# Altium schematic sheet SectionC_BreakOut.SchDoc(SectionC_BreakOut)
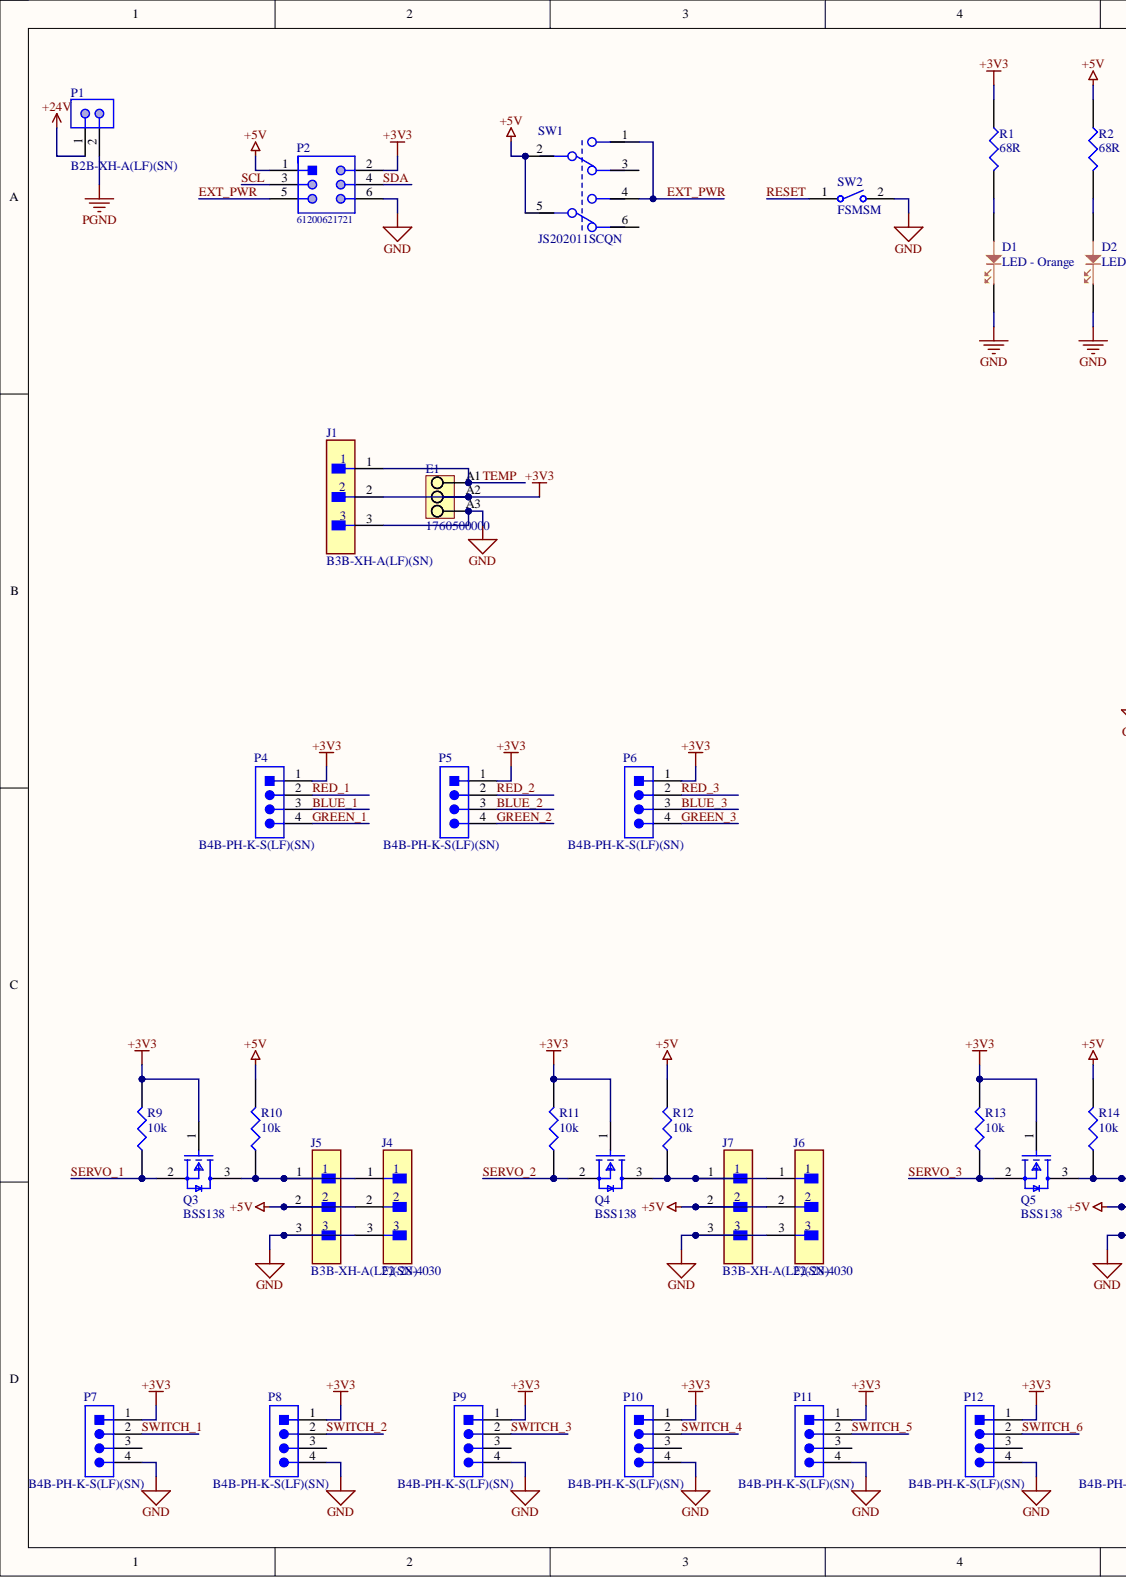
[diagram p0: SectionC_BreakOut.SchDoc, left]
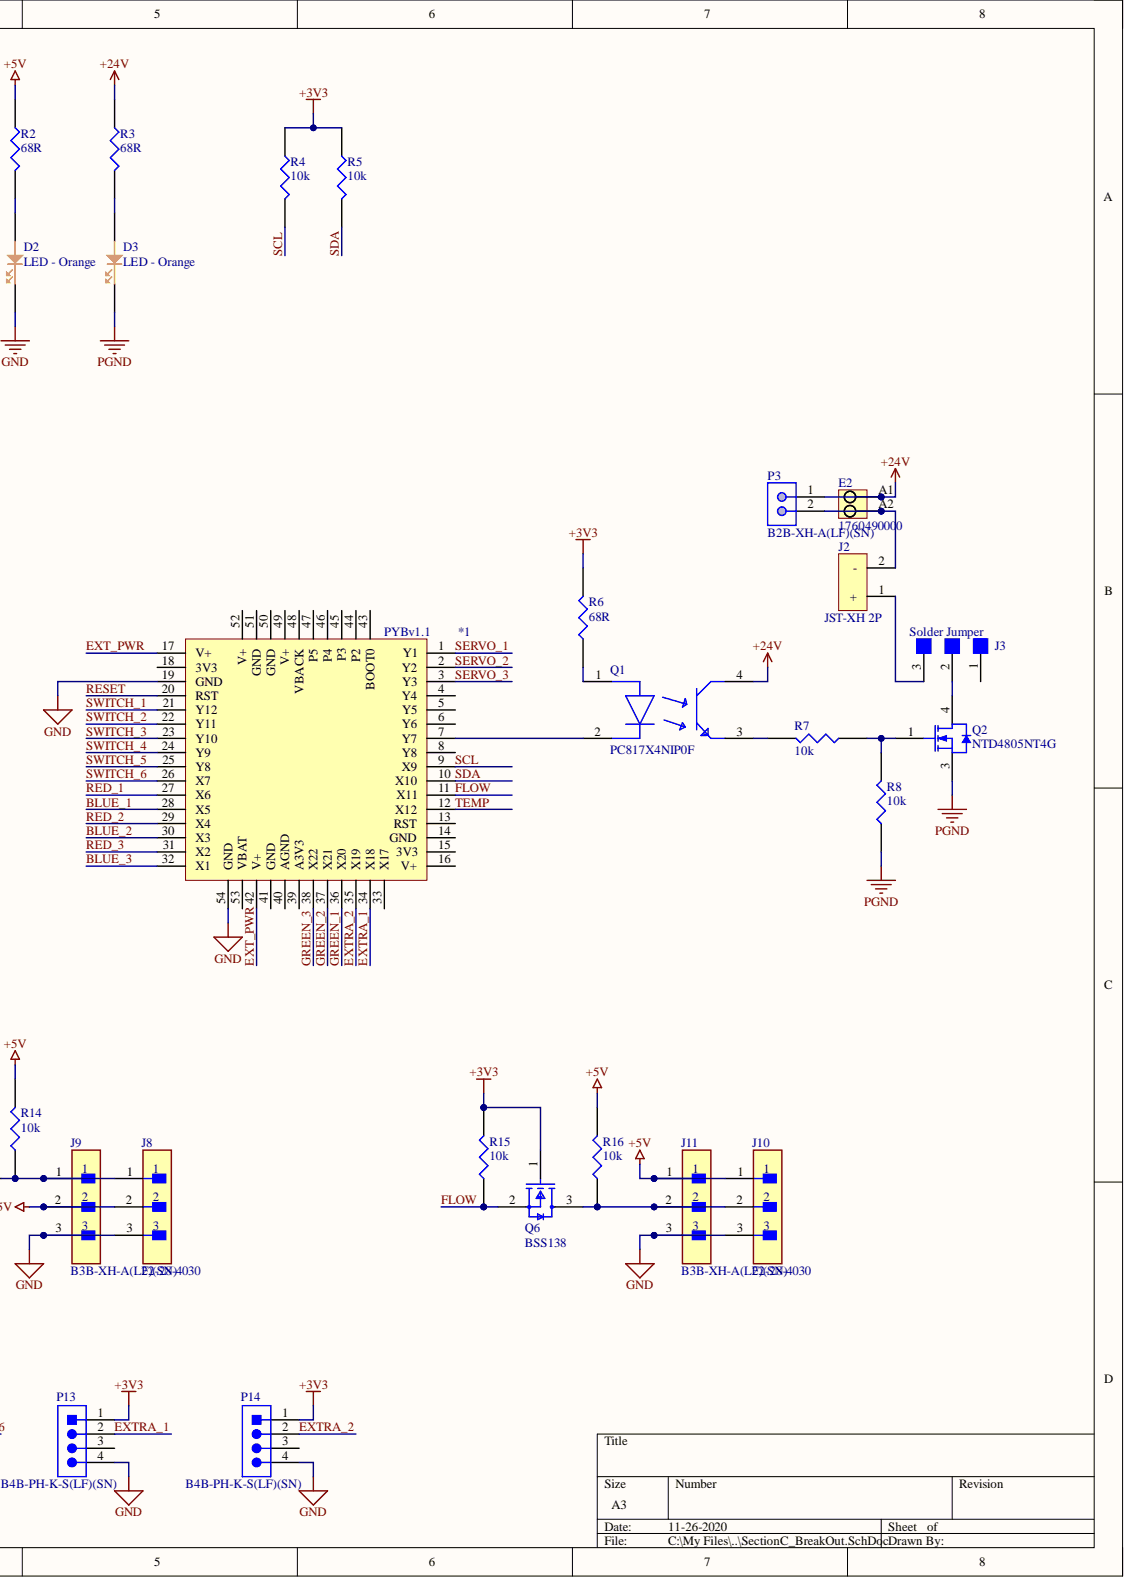
[diagram p1: SectionC_BreakOut.SchDoc, right]

## Parts
*1  PYBv1.1  [PYBv1.1]
D1  LED - Orange  [LED0603-Orange]
D2  LED - Orange  Color: Orange  [LED0603-Orange]
D3  LED - Orange  Color: Orange  [LED0603-Orange]
E1  1760500000  [PM_5_08_90_03]
E2  1760490000  [PM_5_08_90_02]
J1  B3B-XH-A(LF)(SN)  [FP-B3B-XH-A_LF_SN-MFG]
J2  JST-XH 2P  [JST-XH 2P]
J3  Solder Jumper  [Solder Jumper]
J4  22-28-4030  [FP-22-28-4030-MFG]
J5  B3B-XH-A(LF)(SN)  [FP-B3B-XH-A_LF_SN-MFG]
J6  22-28-4030  [FP-22-28-4030-MFG]
J7  B3B-XH-A(LF)(SN)  [FP-B3B-XH-A_LF_SN-MFG]
J8  22-28-4030  [FP-22-28-4030-MFG]
J9  B3B-XH-A(LF)(SN)  [FP-B3B-XH-A_LF_SN-MFG]
J10  22-28-4030  [FP-22-28-4030-MFG]
J11  B3B-XH-A(LF)(SN)  [FP-B3B-XH-A_LF_SN-MFG]
P1  B2B-XH-A(LF)(SN)  [JST-B2B-XH-A_V]
P2  61200621721  [61200621721]
P3  B2B-XH-A(LF)(SN)  [JST-B2B-XH-A_V]
P4  B4B-PH-K-S(LF)(SN)  [JST-B4B-PH-K-S_V]
P5  B4B-PH-K-S(LF)(SN)  [JST-B4B-PH-K-S_V]
P6  B4B-PH-K-S(LF)(SN)  [JST-B4B-PH-K-S_V]
P7  B4B-PH-K-S(LF)(SN)  [JST-B4B-PH-K-S_V]
P8  B4B-PH-K-S(LF)(SN)  [JST-B4B-PH-K-S_V]
P9  B4B-PH-K-S(LF)(SN)  [JST-B4B-PH-K-S_V]
P10  B4B-PH-K-S(LF)(SN)  [JST-B4B-PH-K-S_V]
P11  B4B-PH-K-S(LF)(SN)  [JST-B4B-PH-K-S_V]
P12  B4B-PH-K-S(LF)(SN)  [JST-B4B-PH-K-S_V]
P13  B4B-PH-K-S(LF)(SN)  [JST-B4B-PH-K-S_V]
P14  B4B-PH-K-S(LF)(SN)  [JST-B4B-PH-K-S_V]
Q1  PC817X4NIP0F  [FP-PC817X4NIP0F-MFG]
Q2  NTD4805NT4G  [ONSC-DPAK-3-369AA-01_V]
Q3  BSS138  [FP-318-08-IPC_B]
Q4  BSS138  [FP-318-08-IPC_B]
Q5  BSS138  [FP-318-08-IPC_B]
Q6  BSS138  [FP-318-08-IPC_B]
R1  68R  [68R 0603]
R2  68R  [68R 0603]
R3  68R  [68R 0603]
R4  10k  [10k R0603]
R5  10k  [10k R0603]
R6  68R  [68R 0603]
R7  10k  [10k R0603]
R8  10k  [10k R0603]
R9  10k  [10k R0603]
R10  10k  [10k R0603]
R11  10k  [10k R0603]
R12  10k  [10k R0603]
R13  10k  [10k R0603]
R14  10k  [10k R0603]
R15  10k  [10k R0603]
R16  10k  [10k R0603]
SW1  JS202011SCQN  [FP-JS202011SCQN-MFG]
SW2  FSMSM  [TECO-FSMSM-2_V]

## Nets
+3V3: E1.A2 J1.2 P2.2 P4.1 P5.1 P6.1 P7.1 P8.1 P9.1 P10.1 P11.1 P12.1 P13.1 P14.1 Q3.1 Q4.1 Q5.1 Q6.1 R1.1 R4.1 R5.1 R6.2 R9.2 R11.2 R13.2 R15.2
+5V: J4.2 J5.2 J6.2 J7.2 J8.2 J9.2 J10.1 J11.1 P2.1 R2.1 R10.2 R12.2 R14.2 R16.2 SW1.2 SW1.5
+24V: E2.A1 P1.1 P3.1 Q1.4 R3.1
GND: *1.19(GND) *1.54(GND) D1.1 D2.1 E1.A3 J1.3 J4.3 J5.3 J6.3 J7.3 J8.3 J9.3 J10.3 J11.3 P2.6 P7.4 P8.4 P9.4 P10.4 P11.4 P12.4 P13.4 P14.4 SW2.2
PGND: D3.1 P1.2 Q2.3 R8.1
BLUE_1: *1.28(X5) P4.3
BLUE_2: *1.30(X3) P5.3
BLUE_3: *1.32(X1) P6.3
EXT_PWR: *1.17(V+) *1.42(V+) P2.5 SW1.1 SW1.4
EXTRA_1: *1.34(X18) P13.2
EXTRA_2: *1.35(X19) P14.2
FLOW: *1.11(X11) Q6.2 R15.1
GREEN_1: *1.36(X20) P4.4
GREEN_2: *1.37(X21) P5.4
GREEN_3: *1.38(X22) P6.4
RED_1: *1.27(X6) P4.2
RED_2: *1.29(X4) P5.2
RED_3: *1.31(X2) P6.2
RESET: *1.20(RST) SW2.1
SCL: *1.9(X9) P2.3 R4.2
SDA: *1.10(X10) P2.4 R5.2
SERVO_1: *1.1(Y1) Q3.2 R9.1
SERVO_2: *1.2(Y2) Q4.2 R11.1
SERVO_3: *1.3(Y3) Q5.2 R13.1
SWITCH_1: *1.21(Y12) P7.2
SWITCH_2: *1.22(Y11) P8.2
SWITCH_3: *1.23(Y10) P9.2
SWITCH_4: *1.24(Y9) P10.2
SWITCH_5: *1.25(Y8) P11.2
SWITCH_6: *1.26(X7) P12.2
TEMP: *1.12(X12) E1.A1 J1.1
Net*1_7: *1.7(Y7) Q1.2
NetD1_2: D1.2 R1.2
NetD2_2: D2.2 R2.2
NetD3_2: D3.2 R3.2
NetE2_A2: E2.A2 J2.2 P3.2
NetJ2_1: J2.1 J3.3
NetJ3_2: J3.2 Q2.4
NetJ4_1: J4.1 J5.1 Q3.3 R10.1
NetJ6_1: J6.1 J7.1 Q4.3 R12.1
NetJ8_1: J8.1 J9.1 Q5.3 R14.1
NetJ10_2: J10.2 J11.2 Q6.3 R16.1
NetQ1_1: Q1.1 R6.1
NetQ1_3: Q1.3 R7.2
NetQ2_1: Q2.1 R7.1 R8.2

Not connected: *1 4(Y4) 5(Y5) 6(Y6) 8(Y8) 13(RST) 14(GND) 15(3V3) 16(V+) 18(3V3) 33(X17) 39(A3V3) 40(AGND) 41(GND) 43(BOOT0) 44(P2) 45(P3) 46(P4) 47(P5) 48(VBACK) 49(V+) 50(GND) 51(GND) 52(V+) 53(VBAT); J3 1; P7 3; P8 3; P9 3; P10 3; P11 3; P12 3; P13 3; P14 3; SW1 3 6

## Library data of the parts
D1-D3 = LTST-C191KFKT: LED ORANGE CLEAR SMD; Manufacturer: Vishay Lite-On; Dominant Wavelength: 605nm; Forward Current: 30mA; Forward Voltage: 2V; Lens Color: Colorless; Lens Style: Diffused,Colorless,Rectangular; Luminous Intensity: 90mcd; Number of Elements: 1; Power Dissipation: 75mW; Reverse Voltage: 5V; Test Current: 20mA; Viewing Angle: 130°; Wavelength: 611nm; Case Code (Imperial): 0603; Case Code (Metric): 1608; Case/Package: 0603; Category: LEDs; Component Kind: Standard; ComponentLink1Description: https://datasheet.ciiva.com/2109/ltst-c191kfkt-2109968.pdf?src-supplier=Digi-Key; ComponentLink1URL: https://datasheet.ciiva.com/2109/ltst-c191kfkt-2109968.pdf?src-supplier=Digi-Key; ComponentLink2Description: https://datasheet.ciiva.com/12400/100-ltst-c191kfkt-39904-12400811.pdf?src-supplier=Mouser; ComponentLink2URL: https://datasheet.ciiva.com/12400/100-ltst-c191kfkt-39904-12400811.pdf?src-supplier=Mouser; ComponentLink3Description: https://datasheet.ciiva.com/11775/0900766b80dc02b0-11775613.pdf?src-supplier=RSComponents; ComponentLink3URL: https://datasheet.ciiva.com/11775/0900766b80dc02b0-11775613.pdf?src-supplier=RSComponents; ComponentLink4Description: https://datasheet.ciiva.com/15201/getdatasheetpartid-631075-15201391.pdf?src-supplier=Verical; ComponentLink4URL: https://datasheet.ciiva.com/15201/getdatasheetpartid-631075-15201391.pdf?src-supplier=Verical; ComponentLink5Description: https://datasheet.ciiva.com/2109/s-110-ltst-c191kfkt-2109969.pdf?src-supplier=Digi-Key; ComponentLink5URL: https://datasheet.ciiva.com/2109/s-110-ltst-c191kfkt-2109969.pdf?src-supplier=Digi-Key; Depth: 0.8mm; Height: 0.55mm; Illumination Color: Orange; Lead Free: Lead Free; Length: 1.6mm; Lens Transparency: Clear; Manufacturer Part Number: LTST-C191KFKT; Max Operating Temperature: 85°C; Min Operating Temperature: -55°C; Mount: Surface Mount; Number of LEDs: 1; Number of Pins: 2; Package Quantity: 1; Packaging: Tape and Reel; Power Rating: 75mW; Pricing 1: 1=0.24000, 10=0.17900, 100=0.08460, 500=0.05640, 1000=0.04418, 2500=0.04324 (USD); Radiation Hardening: No; RoHS: Yes; Stock 1: 92562; Supplier 1: Digi-Key; Supplier Part Number 1: 160-1445-1-ND; Width: 0.8mm; Library Name: Limit_Switch_RGB.SchLib
  D1: Color: Orange
E1 = CMP-1559-01629-1: OMNIMATE Signal - series PM; Manufacturer: Weidmueller; Contact_material: Cu-Leg; Contact_surface: tinned; Insulating_material: Wemid (PA); Mounting_onto_the_PCB: Solder connection; No_of_poles: 3; Pitch_inch: 0,2 inch; Pitch_mm: 5,08 mm; Rated_current_use_group_B_UL_1059: 15 A; Rated_voltage_for_surge_voltage_class_pollution_degree_II_2: 600 V; Rated_voltage_use_group_B_UL_1059: 300 V; Short_text_for_material: PM 5.08/03/90 3.5SN OR BX; Side_termination_characteristic: closed side; Solder_pin_length_mm: 3,5 mm; Solid_max_H05_07_V_U: 2,5 mm²; Solid_min_H05_07_V_U: 0,13 mm²; UL_94_flammability_rating: V-0; Wire_cross_section_AWG_max_UL_1059: AWG 14; Wire_cross_section_AWG_min_UL_1059: AWG 26; Colour: Orange; Component Kind: Standard; ComponentLink1Description: Manufacturer URL; ComponentLink1URL: http://www.weidmueller.com/; ComponentLink2Description: URL Link1; ComponentLink2URL: http://catalog.weidmueller.com/catalog/Start.do?localeId=en&ObjectID=1760500000; Library Name: Altium Content Vault; PackageReference: PM_5_08_90_03; Packaging: Box; PartNumber: 1760500000; Qty: 500 PCS; Revision: V1
E2 = CMP-1559-01627-1: OMNIMATE Signal - series PM; Manufacturer: Weidmueller; Contact_material: Cu-Leg; Contact_surface: tinned; Insulating_material: Wemid (PA); Mounting_onto_the_PCB: Solder connection; No_of_poles: 2; Pitch_inch: 0,2 inch; Pitch_mm: 5,08 mm; Rated_current_use_group_B_UL_1059: 15 A; Rated_voltage_for_surge_voltage_class_pollution_degree_II_2: 600 V; Rated_voltage_use_group_B_UL_1059: 300 V; Short_text_for_material: PM 5.08/02/90 3.5SN OR BX; Side_termination_characteristic: closed side; Solder_pin_length_mm: 3,5 mm; Solid_max_H05_07_V_U: 2,5 mm²; Solid_min_H05_07_V_U: 0,13 mm²; UL_94_flammability_rating: V-0; Wire_cross_section_AWG_max_UL_1059: AWG 14; Wire_cross_section_AWG_min_UL_1059: AWG 26; Colour: Orange; Component Kind: Standard; ComponentLink1Description: Manufacturer URL; ComponentLink1URL: http://www.weidmueller.com/; ComponentLink2Description: URL Link1; ComponentLink2URL: http://catalog.weidmueller.com/catalog/Start.do?localeId=en&ObjectID=1760490000; Library Name: Altium Content Vault; PackageReference: PM_5_08_90_02; Packaging: Box; PartNumber: 1760490000; Qty: 500 PCS; Revision: V1
J1 J5 J7 J9 J11 = CMP-17439-000014-1: CONN HEADER VERT 3POS 2.5MM; Manufacturer: JST; Conn Gender: Male; Conn Orientation: Vertical; Current Rating: 3A; Number Of Contacts: 3; Voltage Rating: 250V; Automotive: No; Category: Conn; Component Kind: Standard; ComponentLink2Description: Datasheet; ComponentLink2URL: https://www.jst-mfg.com/product/pdf/eng/eXH.pdf; Contact Material: Tin,Copper; Device Class L1: Connectors; Device Class L2: Headers and Wire Housings; Device Class L3: unset; Digikey Description: CONN HEADER VERT 3POS 2.5MM; Height: 7mm; Lead Free: Yes; Library Name: Altium Content Vault; Manufacturer Part Number: B3B-XH-A(LF)(SN); Number Of Rows: 1; Octopart: https://octopart.com/b3b-xh-a%28lf%29%28sn%29-jst-3990185; Package: 0; Pitch: 2.5mm; RoHS: TRUE; Temperature: -25°C to +85°C; Temperature Range High: +85°C; Temperature Range Low: -25°C
J2 = B2B-XH-A(LF)(SN): JST-XH 2 POS 2.5mm Solder ST Thru-Hole Box; Manufacturer: JST; Connector Type: Male Pin; Contact Resistance: 20mR; Current Rating: 3A; Insulation Resistance: 1GR; Max Voltage Rating (AC): 250V; Number of Contacts: 2; Wire/Cable Gauge: 22AWG; Category: FFC / FPC; Color: Natural; Component Kind: Standard; ComponentLink1Description: https://datasheet.ciiva.com/26894/getdatasheetpartid-640357-26894434.pdf?src-supplier=Verical; ComponentLink1URL: https://datasheet.ciiva.com/26894/getdatasheetpartid-640357-26894434.pdf?src-supplier=Verical; ComponentLink2Description: https://datasheet.ciiva.com/11746/0900766b8082653e-11746979.pdf?src-supplier=RSComponents; ComponentLink2URL: https://datasheet.ciiva.com/11746/0900766b8082653e-11746979.pdf?src-supplier=RSComponents; ComponentLink3Description: https://datasheet.ciiva.com/26894/getdatasheetpartid-385291-26894760.pdf?src-supplier=Verical; ComponentLink3URL: https://datasheet.ciiva.com/26894/getdatasheetpartid-385291-26894760.pdf?src-supplier=Verical; ComponentLink4Description: https://datasheet.ciiva.com/8052/5448-8052024.pdf?src-supplier=Element14; ComponentLink4URL: https://datasheet.ciiva.com/8052/5448-8052024.pdf?src-supplier=Element14; ComponentLink5Description: https://datasheet.ciiva.com/20207/523653-20207167.pdf?src-supplier=Rapid+Electronics; ComponentLink5URL: https://datasheet.ciiva.com/20207/523653-20207167.pdf?src-supplier=Rapid+Electronics; Contact Material: Brass; Contact Plating: Tin,Copper,Lead; Depth: 5.75mm; Fastening Type: Detent; Housing Color: White; Housing Material: Polyamide; Lead Free: Lead Free; Lead Pitch: 2.5mm; Length: 7.4mm; Manufacturer Part Number: B2B-XH-A(LF)(SN); Max Current Rating: 3A; Max Operating Temperature: 85°C; Max Voltage Rating (DC): 250V; Min Operating Temperature: -25°C; Mount: Through Hole; Number of Positions: 2; Number of Rows: 1; Orientation: Straight; Packaging: Bulk; Pitch: 2.5mm; REACH SVHC: No SVHC; RoHS: Yes; Stock 1: 165899; Supplier 1: Element14; Supplier Part Number 1: 1516276; Termination: Solder; Voltage Rating: 250V; Voltage Rating (AC): 250V; Library Name: SectionE_BreakOut.SchLib
J4 J6 J8 J10 = CMP-04776-000098-1: CONN HEADER VERT 3POS 2.54MM; Manufacturer: Molex; Conn Gender: Male; Conn Orientation: Vertical; Current Rating: 4A; Number Of Contacts: 3; Voltage Rating: 500V; Automotive: No; Category: Conn; Component Kind: Standard; ComponentLink2Description: Datasheet; ComponentLink2URL: https://www.molex.com/webdocs/datasheets/pdf/en-us/0022284030_PCB_HEADERS.pdf; Contact Material: Gold,Nickel; Device Class L1: Connectors; Device Class L2: Headers and Wire Housings; Device Class L3: unset; Digikey Description: CONN HEADER VERT 3POS 2.54MM; Footprint Url: https://www.molex.com/pdm_docs/sd/022284030_sd.pdf; Height: 8.88mm; Lead Free: Yes; Library Name: Altium Content Vault; Manufacturer Part Number: 22-28-4030; Mouser Description: Headers & Wire Housings 3P VERT HEADER Sn; Number Of Rows: 1; Octopart: https://octopart.com/0022284030-molex-10476094; Package: 22-28-4030; Pitch: 2.54mm; RoHS: TRUE; Temperature: -40°C to +80°C; Temperature Range High: +80°C; Temperature Range Low: -40°C
P1 P3 = CMP-2000-05888-1: Male Header, Pitch 2.5 mm, 1 x 2 Position, Height 7 mm, Tail Length 3.4 mm, -25 to 85 degC, RoHS, Bulk; Manufacturer: JST; No of Positions: 2; Pitch: 2.5mm; Style/Orientation: StraightHeader/Plug; Component Kind: Standard; Datasheet URL: http://www.jst-mfg.com/product/pdf/eng/eXH.pdf; Datasheet Version: 05/2016; Library Name: Altium Content Vault; Manufacturer URL: http://www.jst.com/home2.html; Mounting Technology: ThroughHole; Package Description: Male Header, Pitch 2.5 mm, 1 x 2 Position, Height 7 mm, Tail Length 3.4 mm; Package Reference: B2B-XH-A; Termination: Crimp; Type: ShroudedHeader
P2 = CMP-1502-00019-1: Male Box Header WR-BHD, THT, Angled, pitch 2.54 mm, 6 pins; Manufacturer: Wurth Elektronik; Contact Resistance: 20mOhm; Pin Number: 6; Pitch: 2.54mm; Withstanding Voltage: 500VAC; Working Voltage: 250VAC; Component Kind: Standard; Datasheet URL: https://katalog.we-online.de/em/datasheet/6120xx21721.pdf; Gender: Male; Library Name: Altium Content Vault; Manufacturer URL: http://www.we-online.de; Mounting Technology: THT; Operating Temperature: -40to125degC; Orientation: Angled; Package Reference: 61200621721; Packaging: Tray; Part Number: 61200621721; Published Date: August2018; Publisher: WurthElektronik
P4-P14 = CMP-2000-05165-1: Male Header, Pitch 2 mm, 1 x 4 Position, Height 6 mm, Tail Length 3.4 mm, -25 to 85 degC, RoHS, Bulk; Manufacturer: JST; No of Positions: 4; Pitch: 2mm; Style/Orientation: StraightHeader/Plug; Component Kind: Standard; Datasheet URL: http://datasheet.octopart.com/B4B-PH-K-S%28LF%29%28SN%29-JST-datasheet-10558886.pdf; Datasheet Version: Apr-09; Library Name: Altium Content Vault; Manufacturer URL: http://www.jst.com/home2.html; Termination: Crimp; Type: ShroudedHeader
Q1 = CMP-65434-000001-1: OPTOISOLATOR 5KV TRANS 4SMD; Manufacturer: Sharp; Collector Emitter Voltage: 80V; Emiiter Collector Voltage: 6V; Forward Current: 20mA; Forward Voltage: 1.2V; Isolation Voltage: 5kVrms; Max Current Transfer Ratio: 600%; Min Current Transfer Ratio: 50%; Power Dissipation: 200mW; Standoff Height: 0.1mm; Automotive: No; Category: Trans; Component Kind: Standard; ComponentLink2Description: Datasheet; ComponentLink2URL: https://www.sharpsde.com/fileadmin/products/Optoelectronics/Isolation%20Devices/Specs%20Photocoupler/PC817XxNIP0F_17Jun08_Spec_ED-04P129A.pdf; Device Class L1: Optoelectronics; Device Class L2: Optocouplers; Device Class L3: unset; Digikey Description: OPTOISOLATOR 5KV TRANS 4SMD; Height: 4.6mm; Lead Free: Yes; Library Name: Altium Content Vault; Manufacturer Part Number: PC817X4NIP0F; Mouser Description: Transistor Output Optocouplers Photocoupler 300-600% CRT; Octopart: https://octopart.com/pc817x4nip0f-sharp-20650453; Package: PC817X4NIP0F; Reverse Voltage: 6V; RoHS: TRUE; Temperature: -30°C to +100°C; Temperature Range High: +100°C; Temperature Range Low: -30°C
Q2 = CMP-1058-00313-1: Power MOSFET, 30 V, 88 A, Single N-Channel, 3-Pin DPAK, Pb-Free, Tape and Reel; Manufacturer: On Semiconductor; Channel Polarity: N Channel; Ciss Typ (pF): 2865; Coss Typ (pF): 610; Crss Typ (pF): 338; ID Max (A): 88; Qrr Typ (nC): 18; V(BR)DSS Min (V): 30; VGS Max (V): 20; VGS(th) Max (V): 2.5; Component Kind: Standard; ComponentLink1Description: Manufacturer URL; ComponentLink1URL: http://www.onsemi.com/; ComponentLink2Description: Datasheet; ComponentLink2URL: http://www.onsemi.cn/pub_link/Collateral/NTD4805N-D.PDF; ComponentLink3Description: Package Specification; ComponentLink3URL: http://www.onsemi.cn/pub_link/Collateral/369AA.PDF; Configuration: Single; DatasheetVersion: Rev. 7; Library Name: Altium Content Vault; Mounting Technology: Surface Mount; Package Type: DPAK-3, IPAK-4; PackageDescription: 3-Pin Flange Mount, Body 6.54 x 6.095 mm, Pitch 2.29 mm; PackageReference: DPAK-3-369AA-01; PackageVersion: Rev. B, 06/2010; Packing: Tape and Reel; PartNumber: NTD4805NT4G; RoHS: Pb-Free
Q3-Q6 = CMP-07173-000101-1: MOSFET N-CH 50V 220MA SOT-23; Drain to Source Resistance: 3.5R; Element Configuration: Single; Fall Time: 9ns; Gate to Source Voltage (Vgs): 20V; Input Capacitance: 27pF; Max Power Dissipation: 360mW; Nominal Vgs: 1.3V; Number of Elements: 1; On-State Resistance: 6R; Turn-Off Delay Time: 20ns; Turn-On Delay Time: 2.5ns; Case/Package: SOT-23; Component Kind: Standard; Contact Plating: Tin; Continuous Drain Current (ID): 220mA; Current Rating: 220mA; Drain to Source Breakdown Voltage: 50V; Drain to Source Voltage (Vdss): 50V; Dual Supply Voltage: 50V; Height: 0.93mm; Lead Free: Lead Free; Length: 2.92mm; Library Name: Altium Content Vault; Max Operating Temperature: 150°C; Min Operating Temperature: -55°C; Mount: Surface Mount; Number of Pins: 3; Package Quantity: 1; Packaging: Tape and Reel; Power Dissipation: 360mW; Radiation Hardening: No; Rds On Max: 3.5R; REACH SVHC: No SVHC; Rise Time: 9ns; Termination: SMD/SMT; Threshold Voltage: 1.3V; Voltage Rating (DC): 50V; Weight: 0.03g; Width: 1.3mm
R1-R3 R6 = CRGP0603F68R: CRGP 0603 68R 1%; Manufacturer: TE Connectivity; Max Power Dissipation: 250mW; Temperature Coefficient: 200ppm/°C; Case Code (Imperial): 0603; Case Code (Metric): 1608; Case/Package: 0603; Category: Chip SMD Resistors; Component Kind: Standard; ComponentLink1Description: https://www.te.com/commerce/DocumentDelivery/DDEController?Action=srchrtrv&amp;DocNm=9-1773463-9&amp;DocType=DS&amp;DocLang=English&src-supplier=Digi-Key; ComponentLink1URL: https://www.te.com/commerce/DocumentDelivery/DDEController?Action=srchrtrv&amp;DocNm=9-1773463-9&amp;DocType=DS&amp;DocLang=English&src-supplier=Digi-Key; Manufacturer Part Number: CRGP0603F68R; Max Operating Temperature: 155°C; Min Operating Temperature: -55°C; Number of Terminations: 2; Packaging: Tape and Reel; Pricing 1: 1=0.14000, 10=0.11400, 100=0.04470, 1000=0.01870, 2500=0.01714 (USD); Resistance: 68R; Resistor Type: Thick Film; RoHS: No; Stock 1: 49308; Supplier 1: Digi-Key; Supplier Part Number 1: A130402CT-ND; Tolerance: 1%; Library Name: Limit_Switch_RGB.SchLib
R4 R5 R7-R16 = CRG0603F10K/10: RES 10.0K OHM 1/10W 1% 0603; Manufacturer: TE Connectivity; Max Power Dissipation: 100mW; Number of Resistors: 1; Resistance: 10kR; Temperature Coefficient: 100ppm/°C; Voltage Rating: 50V; Case Code (Imperial): 0603; Case/Package: 0603; Category: Chip SMD Resistors; Component Kind: Standard; ComponentLink1Description: https://datasheet.ciiva.com/2530/eng-cd-1622829-ba-2530062.pdf?src-supplier=Digi-Key; ComponentLink1URL: https://datasheet.ciiva.com/2530/eng-cd-1622829-ba-2530062.pdf?src-supplier=Digi-Key; Depth: 0.8mm; ELV: Compliant; Height: 0.022inch; Length: 1.6mm; Manufacturer Part Number: CRG0603F10K/10; Max Operating Temperature: 125°C; Military Standard: Not; Min Operating Temperature: -55°C; Mount: Surface Mount; Number of Terminations: 2; Package Quantity: 10000; Packaging: Tape and Reel; Power Rating: 100mW; Pricing 1: 1=0.10000, 10=0.03100, 100=0.01270, 1000=0.00570, 2500=0.00494, 5000=0.00409 (USD); Radiation Hardening: No; Resistor Type: Thick Film; RoHS: Yes; Stock 1: 172089; Supplier 1: Digi-Key; Supplier Part Number 1: A121523CT-ND; Termination: Solder; Tolerance: 1%; Weight: 7.1E-05oz; Width: 0.8mm; Library Name: Limit_Switch_RGB.SchLib
SW1 = CMP-10038-000011-1: SWITCH SLIDE DPDT 300MA 6V; Actuator Length: 2mm; Actuator Material: Nylon; Actuator Type: Standard; Contact Resistance: 70mR; Electrical Life: 5000Cycles; Switch Function: On-On; Component Kind: Standard; Contact Current Rating: 300mA; Contact Material: Copper Alloy; Contact Plating: Silver; Current Rating: 300mA; Depth: 7.5mm; Flammability Rating: UL94 V-2; Housing Material: Nylon; Lead Free: Lead Free; Length: 9mm; Library Name: Altium Content Vault; Max Current Rating: 300mA; Max Operating Temperature: 85°C; Max Voltage Rating (DC): 6V; Min Operating Temperature: -20°C; Mount: Surface Mount; Orientation: Vertical; Package Quantity: 1; Packaging: Tape and Reel; Radiation Hardening: No; REACH SVHC: No SVHC; Switch Travel: 2mm; Termination: Gull Wing; Throw Configuration: DPDT; Voltage Rating (DC): 6V
SW2 = CMP-2000-07511-1: FSMSM Push Button Switch, 50 mA, -35 to 85 degC, 2-Pin SMD, RoHS, Bulk; Manufacturer: TE Connectivity; Actuator Length: 1.07mm; Actuator Material: Polyamide; Case\/Package: SMD/SMT; Contact Current Rating: 50mA; Contact Resistance: 100mOhm; Electrical Life: 20000Cycles; Illumination Type: Illuminated; Max Voltage Rating (DC): 12V; Operating Force: 180gf; Switch Travel: 0.25mm; Throw Configuration: SPST; Voltage Rating (DC): 24V; Component Kind: Standard; Contact Material: Brass; Contact Plating: Silver; Current Rating: 50mA; Datasheet URL: http://www.te.com/commerce/DocumentDelivery/DDEController?Action=showdoc&DocId=Customer+Drawing%7F1437566-3%7FC2%7Fpdf%7FEnglish%7FENG_CD_1437566-3_C2_1437566_3_1_c.dwg.pdf%7F1437566-3; Datasheet Version: Rev. C2, 08/2014; ELV: Compliant; Lead Free: LeadFree; Library Name: Altium Content Vault; Manufacturer URL: http://www.te.com/; Max Operating Temperature: 85degC; Mechanical Life: 20000Cycles; Min Operating Temperature: -35degC; Mount: SurfaceMount; Mounting Technology: SurfaceMount; Orientation: Straight; Package Description: 2-Pin Surface Mount Device, Body 6 x 3.5 mm, Pitch 9.19 mm; Package Quantity: 1000; Package Reference: FSMSM; Packaging: LoosePiece; REACH SVHC: Unknown; RoHSCompliant: true; Termination: GullWing
*1 = PYBv1.1: Component Kind: Standard; Library Name: SectionC_BreakOut.SchLib
J3 = Solder Jumper: Component Kind: Standard; Library Name: SectionE_BreakOut.SchLib
